# Altium schematic sheet Conect.SchDoc(Conect)
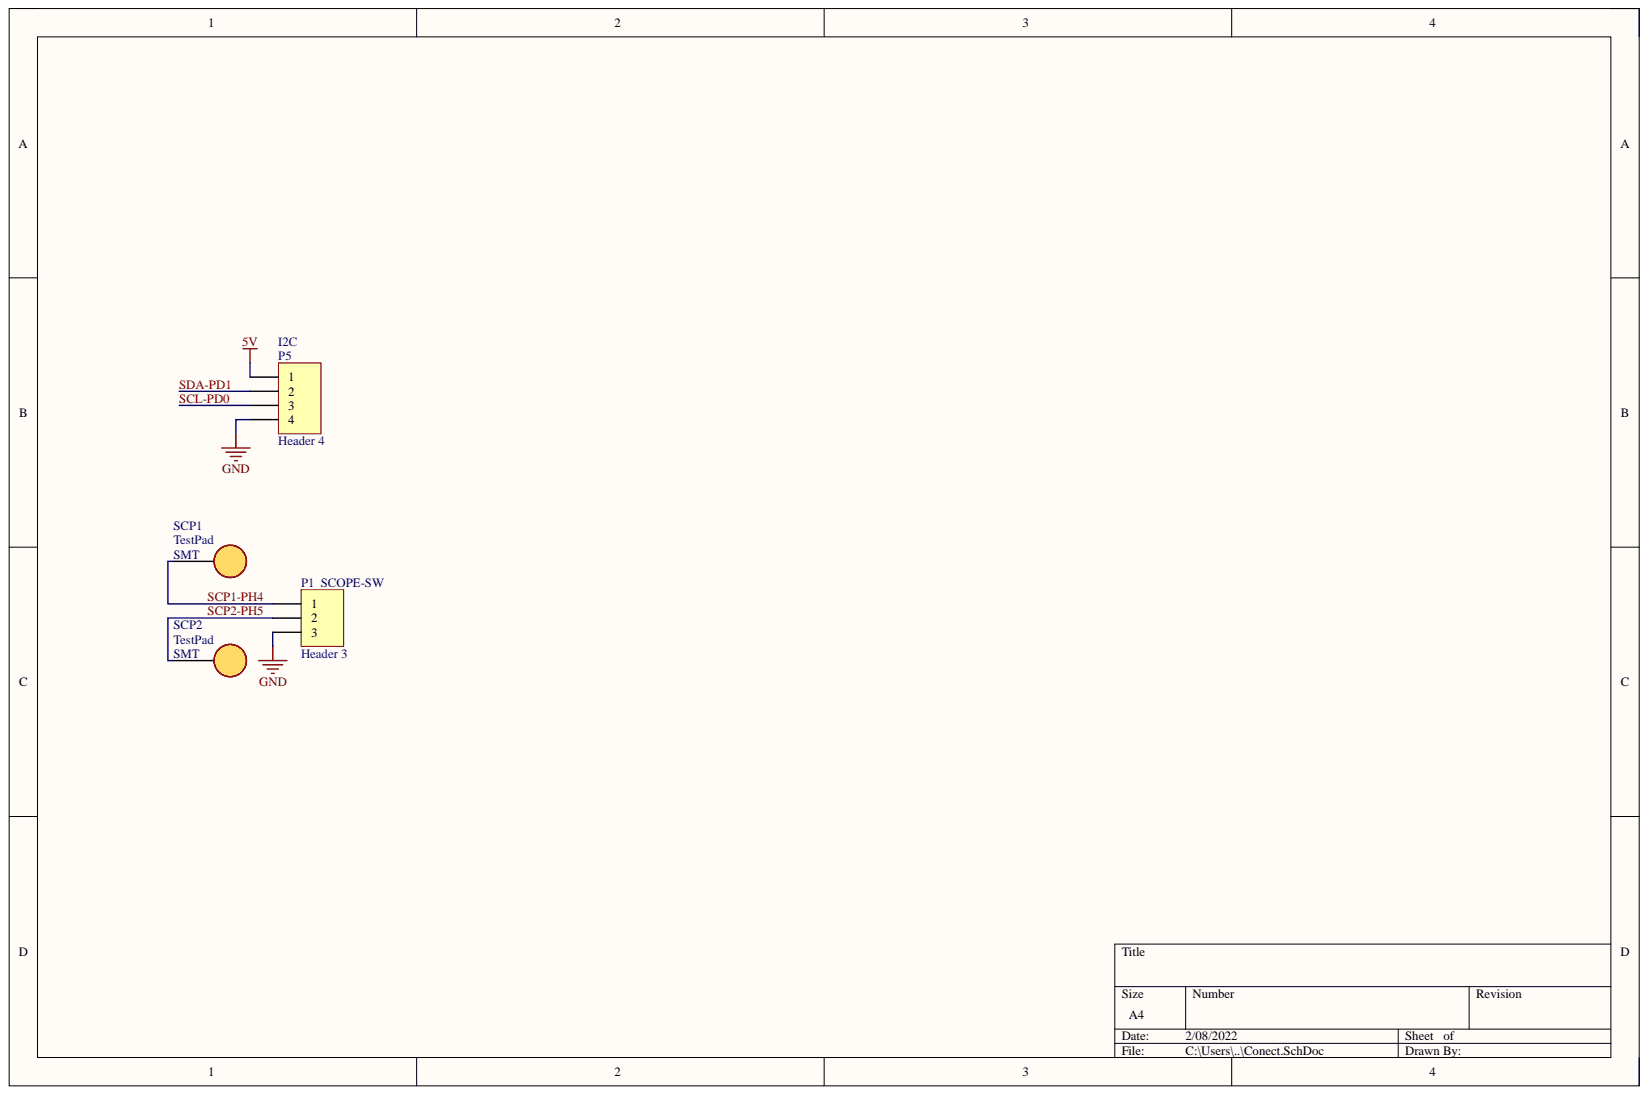
[diagram p0: Conect.SchDoc]

## Parts
P1  Header 3  [HDR1X3]
P5  Header 4  [HDR1X4]
SCP1  (no value)  [TestPAD-SMT-SIMPLES]
SCP2  (no value)  [TestPAD-SMT-SIMPLES]

## Nets
5V: P5.1
GND: P1.3 P5.4
SCL-PD0: P5.3
SCP1-PH4: P1.1 SCP1.1
SCP2-PH5: P1.2 SCP2.1
SDA-PD1: P5.2

## Library data of the parts
P1 = Header 3: Header, 3-Pin; Component Kind: Standard; LatestRevisionDate: 17-Jul-2002; LatestRevisionNote: Re-released for DXP Platform.; Library Name: Miscellaneous Connectors.IntLib; Signal Integrity: Connector; Publisher: Altium Limited
P5 = Header 4: Header, 4-Pin; Component Kind: Standard; LatestRevisionDate: 17-Jul-2002; LatestRevisionNote: Re-released for DXP Platform.; Library Name: Miscellaneous Connectors.IntLib; Signal Integrity: Connector; Publisher: Altium Limited
SCP1 SCP2 = TestPad: Component Kind: Standard; Library Name: TestPadSMT.SchLib
